# Altium schematic sheet [12]Connectors.SchDoc
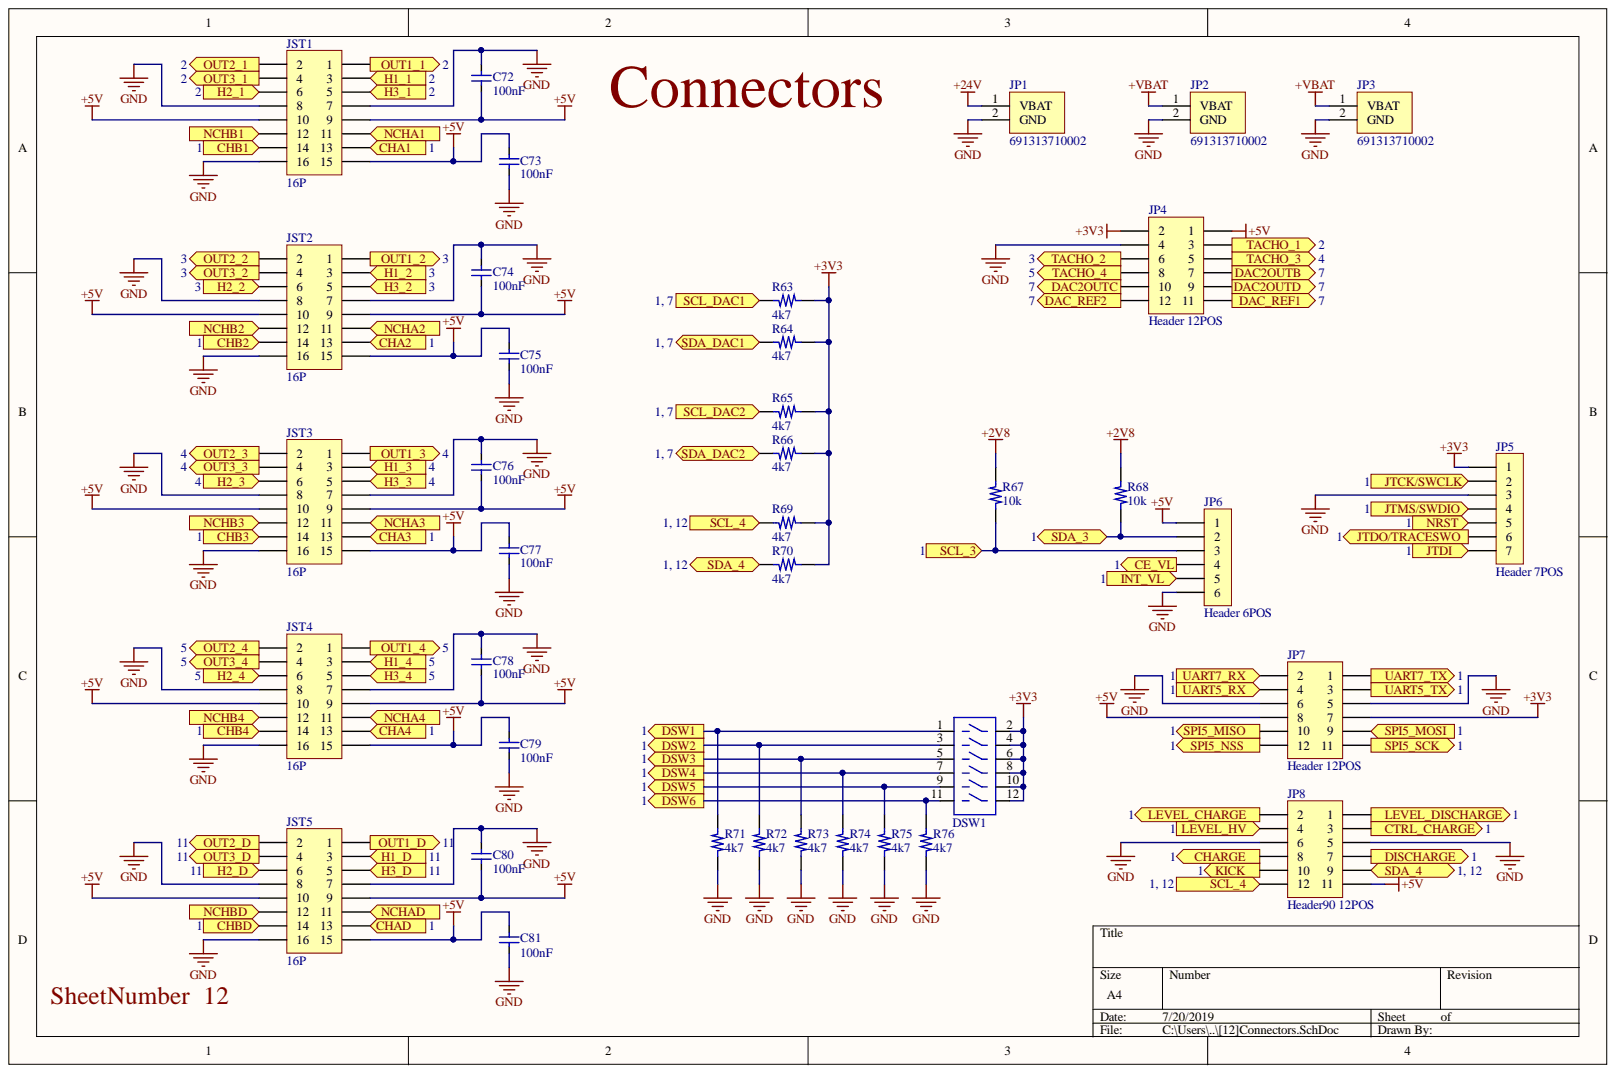
[diagram p0: [12]Connectors.SchDoc]

## Parts
C72  100nF  [C0805]
C73  100nF  [C0805]
C74  100nF  [C0805]
C75  100nF  [C0805]
C76  100nF  [C0805]
C77  100nF  [C0805]
C78  100nF  [C0805]
C79  100nF  [C0805]
C80  100nF  [C0805]
C81  100nF  [C0805]
DSW1  Dip Switch SPST 6 Position  [DIPSWITCH06]  (value not drawn)
JP1  691313710002  [TB02]
JP2  691313710002  [TB02]
JP3  691313710002  [TB02]
JP4  Header 12POS  [HEADER_06X2]
JP5  Header 7POS  [Header_07P]
JP6  Header 6POS  [Header90_06P]
JP7  Header 12POS  [HEADER_06X2]
JP8  Header90 12POS  [HEADER90_06X2]
JST1  16P  [JST16P]
JST2  16P  [JST16P]
JST3  16P  [JST16P]
JST4  16P  [JST16P]
JST5  16P  [JST16P]
R63  4k7  [R0805]
R64  4k7  [R0805]
R65  4k7  [R0805]
R66  4k7  [R0805]
R67  10k  [R0805]
R68  10k  [R0805]
R69  4k7  [R0805]
R70  4k7  [R0805]
R71  4k7  [R0805]
R72  4k7  [R0805]
R73  4k7  [R0805]
R74  4k7  [R0805]
R75  4k7  [R0805]
R76  4k7  [R0805]

## Ports
CE_VL, CHA1, CHA2, CHA3, CHA4, CHAD, CHARGE, CHB1, CHB2, CHB3, CHB4, CHBD, CTRL_CHARGE, DAC2OUTB, DAC2OUTC, DAC2OUTD, DAC_REF1, DAC_REF2, DISCHARGE, DSW1, DSW2, DSW3, DSW4, DSW5, DSW6, H1_1, H1_2, H1_3, H1_4, H1_D, H2_1, H2_2, H2_3, H2_4, H2_D, H3_1, H3_2, H3_3, H3_4, H3_D, INT_VL, JTCK/SWCLK, JTDI, JTDO/TRACESWO, JTMS/SWDIO, KICK, LEVEL_CHARGE, LEVEL_DISCHARGE, LEVEL_HV, NCHA1, NCHA2, NCHA3, NCHA4, NCHAD, NCHB1, NCHB2, NCHB3, NCHB4, NCHBD, NRST, OUT1_1, OUT1_2, OUT1_3, OUT1_4, OUT1_D, OUT2_1, OUT2_2, OUT2_3, OUT2_4, OUT2_D, OUT3_1, OUT3_2, OUT3_3, OUT3_4, OUT3_D, SCL_3, SCL_4, SCL_DAC1, SCL_DAC2, SDA_3, SDA_4, SDA_DAC1, SDA_DAC2, SPI5_MISO, SPI5_MOSI, SPI5_NSS, SPI5_SCK, TACHO_1, TACHO_2, TACHO_3, TACHO_4, UART5_RX, UART5_TX, UART7_RX, UART7_TX

## Nets
+2V8: R67.2 R68.2
+3V3: DSW1.2 DSW1.4 DSW1.6 DSW1.8 DSW1.10 DSW1.12 JP4.2 JP5.1 JP7.7 R63.1 R64.1 R65.1 R66.1 R69.1 R70.1
+5V: C72.1 C73.2 C74.1 C75.2 C76.1 C77.2 C78.1 C79.2 C80.1 C81.2 JP4.1 JP6.1 JP7.8 JP8.11 JST1.9 JST1.10 JST1.15 JST2.9 JST2.10 JST2.15 JST3.9 JST3.10 JST3.15 JST4.9 JST4.10 JST4.15 JST5.9 JST5.10 JST5.15
+24V: JP1.1
+VBAT: JP2.1 JP3.1
GND: C72.2 C73.1 C74.2 C75.1 C76.2 C77.1 C78.2 C79.1 C80.2 C81.1 JP1.2 JP2.2 JP3.2 JP4.4 JP5.3 JP6.6 JP7.5 JP7.6 JP8.5 JP8.6 JST1.7 JST1.8 JST1.16 JST2.7 JST2.8 JST2.16 JST3.7 JST3.8 JST3.16 JST4.7 JST4.8 JST4.16 JST5.7 JST5.8 JST5.16 R71.1 R72.1 R73.1 R74.1 R75.1 R76.1
CE_VL: JP6.4 ; port CE_VL
CHA1: JST1.13 ; port CHA1
CHA2: JST2.13 ; port CHA2
CHA3: JST3.13 ; port CHA3
CHA4: JST4.13 ; port CHA4
CHAD: JST5.13 ; port CHAD
CHARGE: JP8.8 ; port CHARGE
CHB1: JST1.14 ; port CHB1
CHB2: JST2.14 ; port CHB2
CHB3: JST3.14 ; port CHB3
CHB4: JST4.14 ; port CHB4
CHBD: JST5.14 ; port CHBD
CTRL_CHARGE: JP8.3 ; port CTRL_CHARGE
DAC2OUTB: JP4.7 ; port DAC2OUTB
DAC2OUTC: JP4.10 ; port DAC2OUTC
DAC2OUTD: JP4.9 ; port DAC2OUTD
DAC_REF1: JP4.11 ; port DAC_REF1
DAC_REF2: JP4.12 ; port DAC_REF2
DISCHARGE: JP8.7 ; port DISCHARGE
DSW1: DSW1.1 R71.2 ; port DSW1
DSW2: DSW1.3 R72.2 ; port DSW2
DSW3: DSW1.5 R73.2 ; port DSW3
DSW4: DSW1.7 R74.2 ; port DSW4
DSW5: DSW1.9 R75.2 ; port DSW5
DSW6: DSW1.11 R76.2 ; port DSW6
H1_1: JST1.3 ; port H1_1
H1_2: JST2.3 ; port H1_2
H1_3: JST3.3 ; port H1_3
H1_4: JST4.3 ; port H1_4
H1_D: JST5.3 ; port H1_D
H2_1: JST1.6 ; port H2_1
H2_2: JST2.6 ; port H2_2
H2_3: JST3.6 ; port H2_3
H2_4: JST4.6 ; port H2_4
H2_D: JST5.6 ; port H2_D
H3_1: JST1.5 ; port H3_1
H3_2: JST2.5 ; port H3_2
H3_3: JST3.5 ; port H3_3
H3_4: JST4.5 ; port H3_4
H3_D: JST5.5 ; port H3_D
INT_VL: JP6.5 ; port INT_VL
JTCK/SWCLK: JP5.2 ; port JTCK/SWCLK
JTDI: JP5.7 ; port JTDI
JTDO/TRACESWO: JP5.6 ; port JTDO/TRACESWO
JTMS/SWDIO: JP5.4 ; port JTMS/SWDIO
KICK: JP8.10 ; port KICK
LEVEL_CHARGE: JP8.2 ; port LEVEL_CHARGE
LEVEL_DISCHARGE: JP8.1 ; port LEVEL_DISCHARGE
LEVEL_HV: JP8.4 ; port LEVEL_HV
NCHA1: JST1.11 ; port NCHA1
NCHA2: JST2.11 ; port NCHA2
NCHA3: JST3.11 ; port NCHA3
NCHA4: JST4.11 ; port NCHA4
NCHAD: JST5.11 ; port NCHAD
NCHB1: JST1.12 ; port NCHB1
NCHB2: JST2.12 ; port NCHB2
NCHB3: JST3.12 ; port NCHB3
NCHB4: JST4.12 ; port NCHB4
NCHBD: JST5.12 ; port NCHBD
NRST: JP5.5 ; port NRST
OUT1_1: JST1.1 ; port OUT1_1
OUT1_2: JST2.1 ; port OUT1_2
OUT1_3: JST3.1 ; port OUT1_3
OUT1_4: JST4.1 ; port OUT1_4
OUT1_D: JST5.1 ; port OUT1_D
OUT2_1: JST1.2 ; port OUT2_1
OUT2_2: JST2.2 ; port OUT2_2
OUT2_3: JST3.2 ; port OUT2_3
OUT2_4: JST4.2 ; port OUT2_4
OUT2_D: JST5.2 ; port OUT2_D
OUT3_1: JST1.4 ; port OUT3_1
OUT3_2: JST2.4 ; port OUT3_2
OUT3_3: JST3.4 ; port OUT3_3
OUT3_4: JST4.4 ; port OUT3_4
OUT3_D: JST5.4 ; port OUT3_D
SCL_3: JP6.3 R67.1 ; port SCL_3
SCL_4: JP8.12 R69.2 ; port SCL_4
SCL_DAC1: R63.2 ; port SCL_DAC1
SCL_DAC2: R65.2 ; port SCL_DAC2
SDA_3: JP6.2 R68.1 ; port SDA_3
SDA_4: JP8.9 R70.2 ; port SDA_4
SDA_DAC1: R64.2 ; port SDA_DAC1
SDA_DAC2: R66.2 ; port SDA_DAC2
SPI5_MISO: JP7.10 ; port SPI5_MISO
SPI5_MOSI: JP7.9 ; port SPI5_MOSI
SPI5_NSS: JP7.12 ; port SPI5_NSS
SPI5_SCK: JP7.11 ; port SPI5_SCK
TACHO_1: JP4.3 ; port TACHO_1
TACHO_2: JP4.6 ; port TACHO_2
TACHO_3: JP4.5 ; port TACHO_3
TACHO_4: JP4.8 ; port TACHO_4
UART5_RX: JP7.4 ; port UART5_RX
UART5_TX: JP7.3 ; port UART5_TX
UART7_RX: JP7.2 ; port UART7_RX
UART7_TX: JP7.1 ; port UART7_TX

## Library data of the parts
C72-C81 = CC0805ZRY5V9BB104: CAP CER 0.1UF 50V Y5V 0805; Mfr 1: Yageo; Component Kind: Standard; Library Name: Components.SchLib; Mfr 1 PN: CC0805ZRY5V9BB104
DSW1 = TDA06H0SB1R: Dip Switch SPST 6 Position Surface Mount Slide (Standard) Actuator 25mA 24VDC; Mfr 1: C&K; Component Kind: Standard; Library Name: Components.SchLib; Mfr 1 PN: TDA06H0SB1R
JP1-JP3 = 691313710002: TERM BLOCK HDR 2POS 90DEG 5MM; Mfr 1: Wurth Electronics Inc.; Component Kind: Standard; Library Name: Components.SchLib; Mfr 1 PN: 691313710002
JP4 JP7 = 61301221121: CONN HEADER VERT 12POS 2.54MM; Mfr 1: Wurth Electronics Inc.; Component Kind: Standard; Library Name: Components.SchLib; Mfr 1 PN: 61301221121
JP5 = M20-9770746: CONN HEADER VERT 7POS 2.54MM; Mfr 1: Harwin Inc.; Component Kind: Standard; Library Name: Components.SchLib; Mfr 1 PN: M20-9770746
JP6 = M20-9750646: CONN HEADER R/A 6POS 2.54MM; Mfr 1: Harwin Inc.; Component Kind: Standard; Library Name: Components.SchLib; Mfr 1 PN: M20-9750646
JP8 = 61301221021: CONN HEADER R/A 12POS 2.54MM; Mfr 1: Wurth Electronics Inc.; Component Kind: Standard; Library Name: Components.SchLib; Mfr 1 PN: 61301221021
JST1-JST5 = S16B-PHDSS(LF)(SN): CONN HEADER PHD SIDE 16POS 2MM; Mfr 1: JST Sales America Inc.; Component Kind: Standard; Library Name: Components.SchLib; Mfr 1 PN: S16B-PHDSS(LF)(SN)
R63-R66 R69-R76 = CRG0805F4K7: RES SMD 4.7K OHM 1% 1/8W 0805; Mfr 1: TE Connectivity Passive Product; Component Kind: Standard; Library Name: Components.SchLib; Mfr 1 PN: CRG0805F4K7
R67 R68 = RC0805FR-0710KL: RES SMD 10K OHM 1% 1/8W 0805; Mfr 1: Yageo; Component Kind: Standard; Library Name: Components.SchLib; Mfr 1 PN: RC0805FR-0710KL
